# Altium schematic sheet motherboard.SchDoc
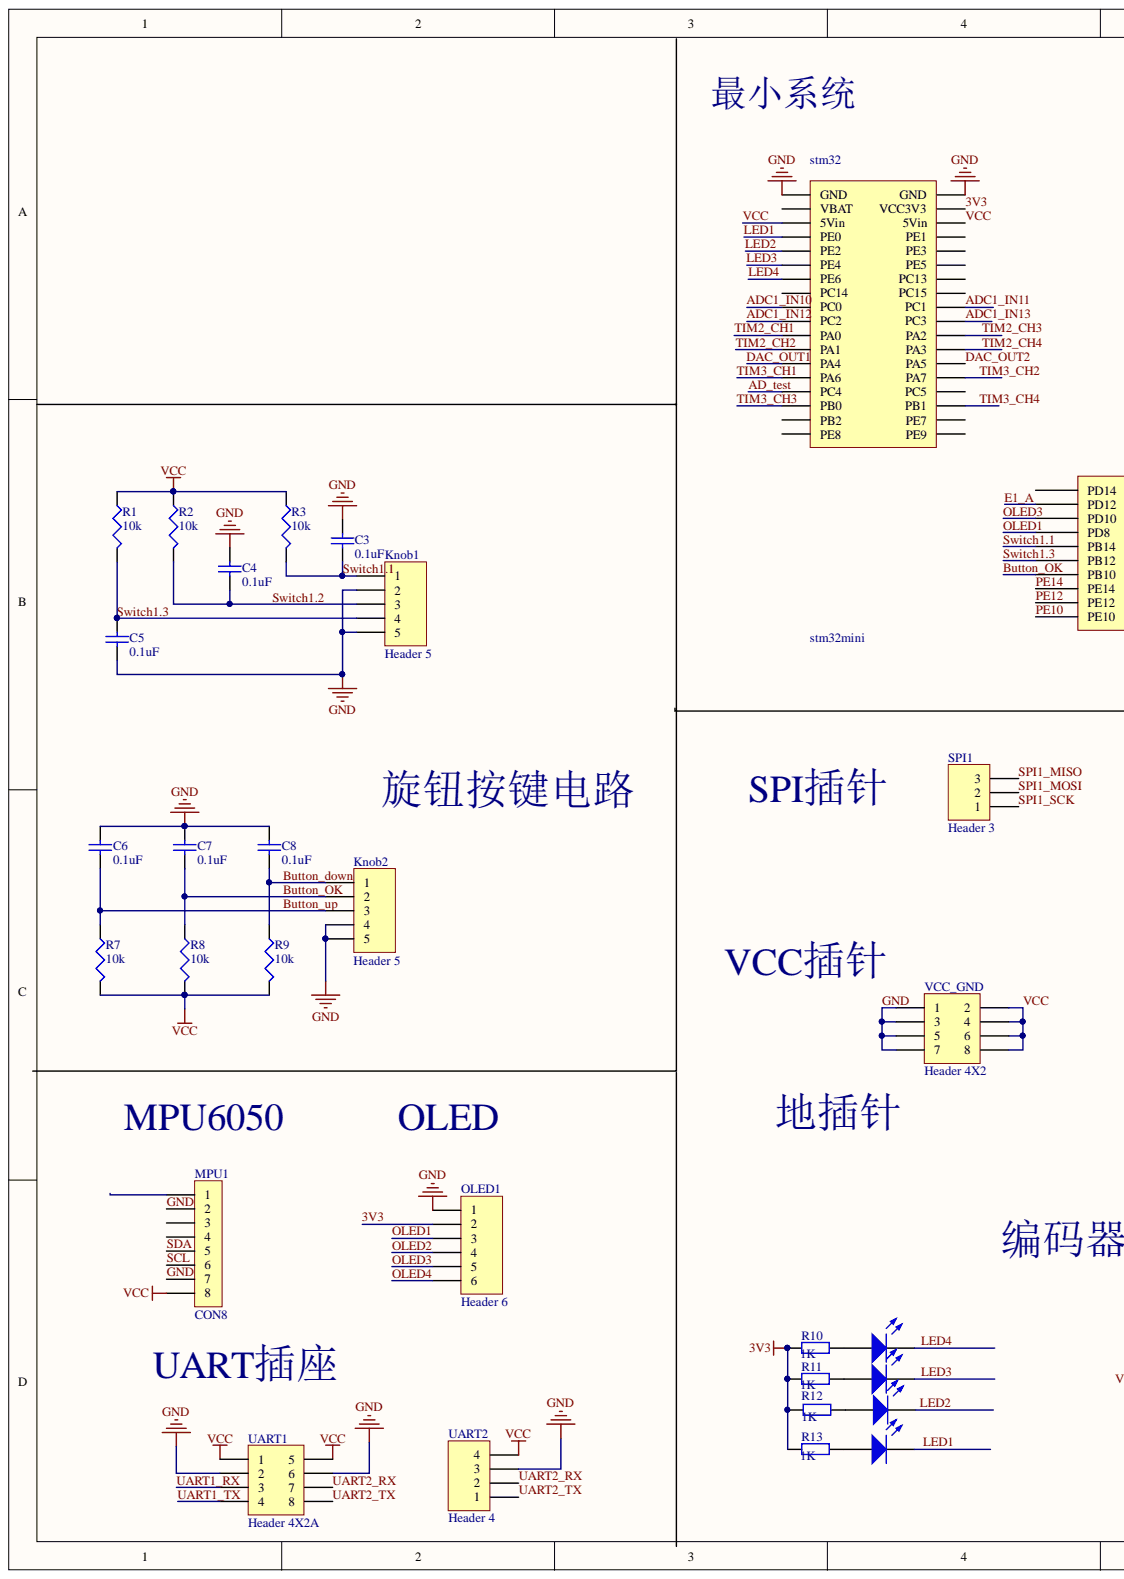
[diagram p0: motherboard.SchDoc, left]
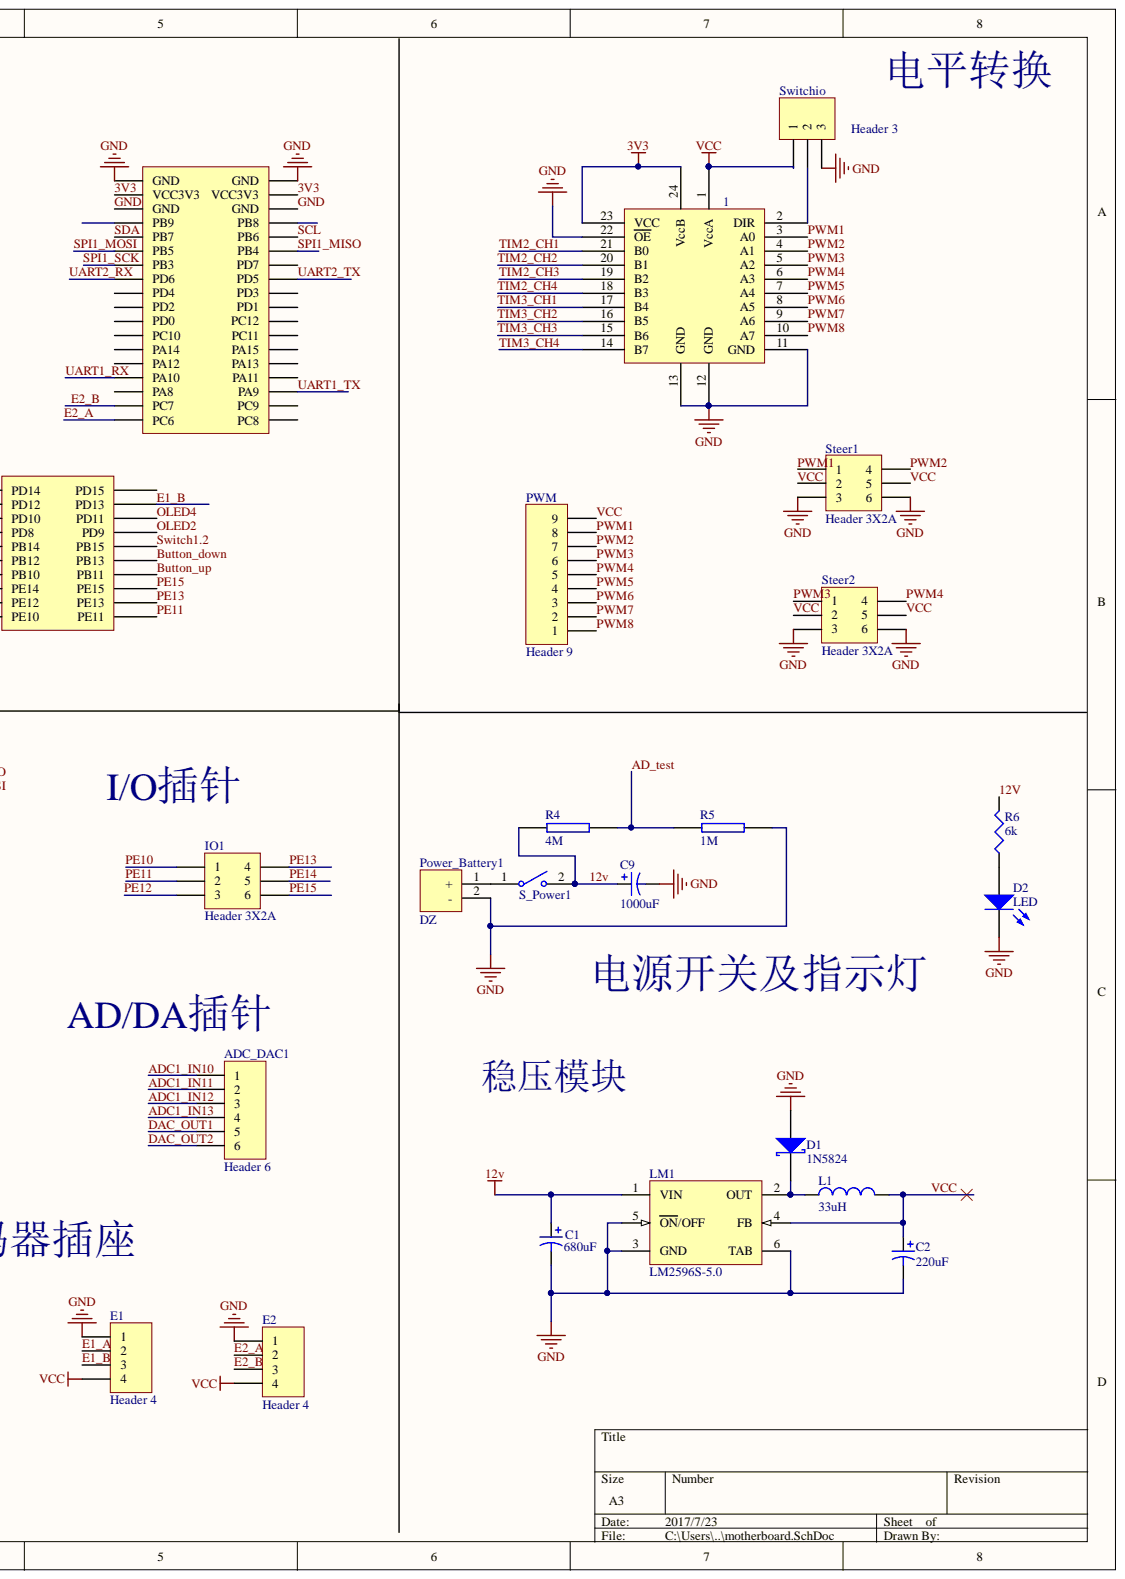
[diagram p1: motherboard.SchDoc, right]

## Parts
1  74LVC4245A  [4245-TSSOP]  (value not drawn)
ADC_DAC1  Header 6  [HDR1X6]
C1  680uF  [铝解电容]
C2  220uF  [铝解电容]
C3  0.1uF  [0603C]
C4  0.1uF  [0603C]
C5  0.1uF  [0603C]
C6  0.1uF  [0603C]
C7  0.1uF  [0603C]
C8  0.1uF  [0603C]
C9  1000uF  [铝解电容]
D1  1N5824  [1N5819/22]
D2  LED  [0603_LED]
D3  LED2  [0603_LED]
D4  LED2  [0603_LED]
D5  LED2  [0603_LED]
D6  LED2  [0603_LED]
E1  Header 4  [HDR1X4]
E2  Header 4  [HDR1X4]
IO1  Header 3X2A  [HDR2X3_CEN]
Knob1  Header 5  [KNOB]
Knob2  Header 5  [拨轮开关]
L1  33uH  [CDRH74-33]
LM1  LM2596S-5.0  [TS5B_L]
MPU1  CON8  [PCBComponent_1 - duplicate]
OLED1  Header 6  [HDR1X6]
Power_Battery1  DZ
PWM  Header 9  [HDR1X9]
R1  10k  [0603R]
R2  10k  [0603R]
R3  10k  [0603R]
R4  4M  [0603R]
R5  1M  [0603R]
R6  6k  [0603R]
R7  10k  [0603R]
R8  10k  [0603R]
R9  10k  [0603R]
R10  1k  [0603R]
R11  1k  [0603R]
R12  1k  [0603R]
R13  1k  [0603R]
S_Power1  (no value)  [Switch_Small]
SPI1  Header 3  [HDR1X3]
Steer1  Header 3X2A  [HDR2X3_CEN]
Steer2  Header 3X2A  [HDR2X3_CEN]
stm32  stm32mini  [stm32mini]
Switchio  Header 3  [HDR1X3]
UART1  Header 4X2A  [HDR2X4_CEN]
UART2  Header 4  [HDR1X4]
VCC_GND  Header 4X2  [HDR2X4]

## Nets
3V3: 1.23(VCC) 1.24(VccB) OLED1.2 R10.1 R11.1 R12.1 R13.1 stm32.4 stm32.89(VCC3V3) stm32.90
12V: C1.1 C9.1 LM1.1(VIN) R4.1 R6.2 S_Power1.2
AD_TEST: R4.2 R5.1 stm32.29(PC4)
ADC1_IN10: ADC_DAC1.1 stm32.17(PC0)
ADC1_IN11: ADC_DAC1.2 stm32.18
ADC1_IN12: ADC_DAC1.3 stm32.19(PC2)
ADC1_IN13: ADC_DAC1.4 stm32.20
BUTTON_DOWN: C8.1 Knob2.1 R9.1 stm32.45(PB13)
BUTTON_OK: C7.1 Knob2.2 R8.1 stm32.44(PB10)
BUTTON_UP: C6.1 Knob2.3 R7.1 stm32.43(PB11)
DAC_OUT1: ADC_DAC1.5 stm32.25(PA4)
DAC_OUT2: ADC_DAC1.6 stm32.26
E1_A: E1.2 stm32.54(PD12)
E1_B: E1.3 stm32.53(PD13)
E2_A: E2.2 stm32.57
E2_B: E2.3 stm32.58
GND: 1.11(GND) 1.12(GND) 1.13(GND) 1.22(OE) C1.2 C2.2 C3.2 C4.2 C5.1 C6.2 C7.2 C8.2 C9.2 D1.1 D2.2 E1.1 E2.1 Knob1.2 Knob1.5 Knob2.4 Knob2.5 LM1.3(GND) LM1.5(ON/OFF) LM1.6(TAB) MPU1.2 MPU1.7 OLED1.1 Power_Battery1.2 R5.2 Steer1.3 Steer1.6 Steer2.3 Steer2.6 stm32.1(GND) stm32.2 stm32.87(GND) stm32.88 stm32.91(GND) stm32.92 Switchio.3 UART1.2 UART1.6 UART2.3 VCC_GND.1 VCC_GND.3 VCC_GND.5 VCC_GND.7
LED1: D6.2 stm32.7(PE0)
LED2: D5.2 stm32.9(PE2)
LED3: D4.2 stm32.11(PE4)
LED4: D3.2 stm32.13(PE6)
OLED1: OLED1.3 stm32.50(PD8)
OLED2: OLED1.4 stm32.49(PD9)
OLED3: OLED1.5 stm32.52(PD10)
OLED4: OLED1.6 stm32.51(PD11)
PE10: IO1.1 stm32.38(PE10)
PE11: IO1.2 stm32.37(PE11)
PE12: IO1.3 stm32.40(PE12)
PE13: IO1.4 stm32.39(PE13)
PE14: IO1.5 stm32.42(PE14)
PE15: IO1.6 stm32.41(PE15)
PWM1: 1.3(A0) PWM.8 Steer1.1
PWM2: 1.4(A1) PWM.7 Steer1.4
PWM3: 1.5(A2) PWM.6 Steer2.1
PWM4: 1.6(A3) PWM.5 Steer2.4
PWM5: 1.7(A4) PWM.4
PWM6: 1.8(A5) PWM.3
PWM7: 1.9(A6) PWM.2
PWM8: 1.10(A7) PWM.1
SCL: MPU1.6 stm32.83(PB6)
SDA: MPU1.5 stm32.84
SPI1_MISO: SPI1.3 stm32.81(PB4)
SPI1_MOSI: SPI1.2 stm32.82
SPI1_SCK: SPI1.1 stm32.80
SWITCH1.1: C3.1 Knob1.1 R3.2 stm32.48(PB14)
SWITCH1.2: C4.1 Knob1.3 R2.2 stm32.47(PB15)
SWITCH1.3: C5.2 Knob1.4 R1.2 stm32.46(PB12)
TIM2_CH1: 1.21(B0) stm32.21(PA0)
TIM2_CH2: 1.20(B1) stm32.23(PA1)
TIM2_CH3: 1.19(B2) stm32.22
TIM2_CH4: 1.18(B3) stm32.24
TIM3_CH1: 1.17(B4) stm32.27(PA6)
TIM3_CH2: 1.16(B5) stm32.28
TIM3_CH3: 1.15(B6) stm32.31(PB0)
TIM3_CH4: 1.14(B7) stm32.32
UART1_RX: stm32.64 UART1.3
UART1_TX: stm32.61(PA9) UART1.4
UART2_RX: stm32.78 UART1.7 UART2.2
UART2_TX: stm32.77(PD5) UART1.8 UART2.1
VCC: 1.1(VccA) C2.1 E1.4 E2.4 L1.2 LM1.4(FB) MPU1.8 PWM.9 R1.1 R2.1 R3.1 R7.2 R8.2 R9.2 Steer1.2 Steer1.5 Steer2.2 Steer2.5 stm32.5(5Vin) stm32.6 Switchio.1 UART1.1 UART1.5 UART2.4 VCC_GND.2 VCC_GND.4 VCC_GND.6 VCC_GND.8
Net1_2: 1.2(DIR) Switchio.2
NetD1_2: D1.2 L1.1 LM1.2(OUT)
NetD2_1: D2.1 R6.1
NetD3_1: D3.1 R10.2
NetD4_1: D4.1 R11.2
NetD5_1: D5.1 R12.2
NetD6_1: D6.1 R13.2
NetPower_Battery1_1: Power_Battery1.1 S_Power1.1

Not connected: MPU1 1 3 4; stm32 3(VBAT) 8 10 12 14 15(PC14) 16 30 33(PB2) 34 35(PE8) 36 55(PD15) 56(PD14) 59(PC8) 60(PC9) 62 63(PA11) 65(PA13) 66 67(PA15) 68 69(PC11) 70 71(PC12) 72 73(PD1) 74 75(PD3) 76 79(PD7) 85(PB8) 86

## Library data of the parts
ADC_DAC1 OLED1 = Header 6: Header, 6-Pin; Component Kind: Standard; LatestRevisionDate: 17-Jul-2002; LatestRevisionNote: Re-released for DXP Platform.; Library Name: Miscellaneous Connectors.IntLib; Signal Integrity: Connector; Publisher: Altium Limited
C1 C2 = Cap Pol3: Polarized Capacitor (Surface Mount); Component Kind: Standard; LatestRevisionDate: 29-May-2009; LatestRevisionNote: IPC-7351 Footprint Added.; Library Name: Miscellaneous Devices.IntLib; PackageDescription: Chip Capacitor; PackageReference: C0805; Published: 8-Jun-2000; Signal Integrity: Cap Pol; Simulation: CAP; Publisher: Altium Limited
C3-C8 = Cap: Capacitor; Component Kind: Standard; LatestRevisionDate: 17-Jul-2002; LatestRevisionNote: Re-released for DXP Platform.; Library Name: Miscellaneous Devices.IntLib; PackageReference: RAD-0.3; Published: 8-Jun-2000; Signal Integrity: Cap; Simulation: CAP; Publisher: Altium Limited
C9 = Cap Pol1: Polarized Capacitor (Radial); Component Kind: Standard; LatestRevisionDate: 17-Jul-2002; LatestRevisionNote: Re-released for DXP Platform.; Library Name: Miscellaneous Devices.IntLib; PackageDescription: Polarized Capacitor (Radial); 2 Leads; PackageReference: RB7.6-15; Published: 8-Jun-2000; Signal Integrity: Cap Pol; Simulation: CAP; Publisher: Altium Limited
D1 = 1N5819/22: Component Kind: Standard; Library Name: Mainboard.IntLib
D2 = LED0: Typical INFRARED GaAs LED; Component Kind: Standard; LatestRevisionDate: 08-Jul-2005; LatestRevisionNote: Mech Layer 1 removed.; Library Name: Miscellaneous Devices.IntLib; PackageDescription: LED; 2 Leads; PackageReference: LED-0; Published: 8-Jun-2000; Publisher: Altium Limited
D3-D6 = LED2: Typical RED, GREEN, YELLOW, AMBER GaAs LED; Component Kind: Standard; LatestRevisionDate: 17-Jul-2002; LatestRevisionNote: Re-released for DXP Platform.; Library Name: Miscellaneous Devices.IntLib; PackageDescription: SM LED; 2 Flat Leads; PackageReference: 3.2X1.6X1.1; PackageVersion: Feb-2002; Published: 8-Jun-2000; Simulation: LED2; Publisher: Altium Limited
E1 E2 UART2 = Header 4: Header, 4-Pin; Component Kind: Standard; LatestRevisionDate: 17-Jul-2002; LatestRevisionNote: Re-released for DXP Platform.; Library Name: Miscellaneous Connectors.IntLib; Signal Integrity: Connector; Publisher: Altium Limited
IO1 Steer1 Steer2 = Header 3X2A: Header, 3-Pin, Dual row; Component Kind: Standard; LatestRevisionDate: 17-Jul-2002; LatestRevisionNote: Re-released for DXP Platform.; Library Name: Miscellaneous Connectors.IntLib; Signal Integrity: Connector; Publisher: Altium Limited
Knob1 Knob2 = Header 5: Header, 5-Pin; Component Kind: Standard; LatestRevisionDate: 17-Jul-2002; LatestRevisionNote: Re-released for DXP Platform.; Library Name: Miscellaneous Connectors.IntLib; Signal Integrity: Connector; Publisher: Altium Limited
L1 = Inductor: Code_IEC: 1005; Code_JEITA: 0402; Component Kind: Standard; Description: Inductor; LatestRevisionDate: 29-May-2009; LatestRevisionNote: IPC-7351 Footprint Added.; Library Name: Miscellaneous Devices.IntLib; PackageDescription: Chip Inductor; PackageReference: 0402-A; Published: 8-Jun-2000; Signal Integrity: Inductor; Simulation: INDUCTOR; Publisher: Altium Limited
LM1 = LM2596S-5.0/NOPB: SIMPLE SWITCHER?Power Converter 150 KHz 3A Step-Down Voltage Regulator, 5-pin TO-263, Pb-Free; Manufacturer: National Semiconductor; PackageTopMarking: NSUZXYTTE#*LM2596S*-5.0 P+; PowerWise: N; Code_JEDEC: MO-169-AB; Component Kind: Standard; ComponentLink1Description: LM2596 Datasheet; ComponentLink1URL: http://www.national.com/ds/LM/LM2596.pdf; ComponentLink2Description: LM2596 Web Page; ComponentLink2URL: http://www.national.com/pf/LM/LM2596.html; ComponentLink3Description: TS5B Package Page; ComponentLink3URL: http://www.national.com/packaging/folders/ts5b.html; CompType: Switcher; DatasheetVersion: May-2002; Library Name: NSC Switcher.IntLib; PackageDescription: TO-263, Molded, .400 x .390 x .180in, 5 Lead, .067in Pitch, Surface Mount; PackageReference: TS5B; PackageVersion: revD, Apr-2005; PartNumber: LM2596S-5.0/NOPB; PinCount: 5; Published: 1-Mar-2010; RoHS: Y; Supplier 1: Digi-Key; Supplier 2: Farnell; Supplier Part Number 1: LM2596S-5.0-ND; Supplier Part Number 2: 1469195; Publisher: Altium Limited
MPU1 = CON8: Connector; Component Kind: Standard; Library Name: 插件.SCHLIB
Power_Battery1 = T_Head: Component Kind: Standard; Library Name: CCD_main.IntLib
PWM = Header 9: Header, 9-Pin; Component Kind: Standard; LatestRevisionDate: 17-Jul-2002; LatestRevisionNote: Re-released for DXP Platform.; Library Name: Miscellaneous Connectors.IntLib; Signal Integrity: Connector; Publisher: Altium Limited
R1-R3 R6-R9 = Res3: Resistor; Component Kind: Standard; LatestRevisionDate: 29-May-2009; LatestRevisionNote: IPC-7351 Footprint Added.; Library Name: Miscellaneous Devices.IntLib; PackageDescription: Chip Resistor; PackageReference: J1-0603; Published: 8-Jun-2000; Signal Integrity: Res; Simulation: RESISTOR; Publisher: Altium Limited
R4 R5 = RES2: Resistor; Component Kind: Standard; Library Name: 常用1.SchLib
R10-R13 = Res2: Resistor; Component Kind: Standard; LatestRevisionDate: 17-Jul-2002; LatestRevisionNote: Re-released for DXP Platform.; Library Name: Miscellaneous Devices.IntLib; PackageDescription: Axial Device, Thru-Hole; 2 Leads; 0.4 in Pin Spacing; PackageReference: AXIAL-0.4; Published: 8-Jun-2000; Signal Integrity: Res; Simulation: RESISTOR; Value: 1K; Publisher: Altium Limited
S_Power1 = Switch: : 电源总开关; Component Kind: Standard; Library Name: Mainboard_Library.IntLib
SPI1 Switchio = Header 3: Header, 3-Pin; Component Kind: Standard; LatestRevisionDate: 17-Jul-2002; LatestRevisionNote: Re-released for DXP Platform.; Library Name: Miscellaneous Connectors.IntLib; Signal Integrity: Connector; Publisher: Altium Limited
UART1 = Header 4X2A: Header, 4-Pin, Dual row; Component Kind: Standard; LatestRevisionDate: 17-Jul-2002; LatestRevisionNote: Re-released for DXP Platform.; Library Name: Miscellaneous Connectors.IntLib; Signal Integrity: Connector; Publisher: Altium Limited
VCC_GND = Header 4X2: Header, 4-Pin, Dual row; Component Kind: Standard; LatestRevisionDate: 17-Jul-2002; LatestRevisionNote: Re-released for DXP Platform.; Library Name: Miscellaneous Connectors.IntLib; Signal Integrity: Connector; Publisher: Altium Limited
1 = 74LVC4245A: Component Kind: Standard; Library Name: Mainboard.IntLib
stm32 = stm32mini: Component Kind: Standard; Library Name: STM32F407VET6mini最小系统-原理图库.SCHLIB
